# Altium schematic sheet Sheet1.SchDoc
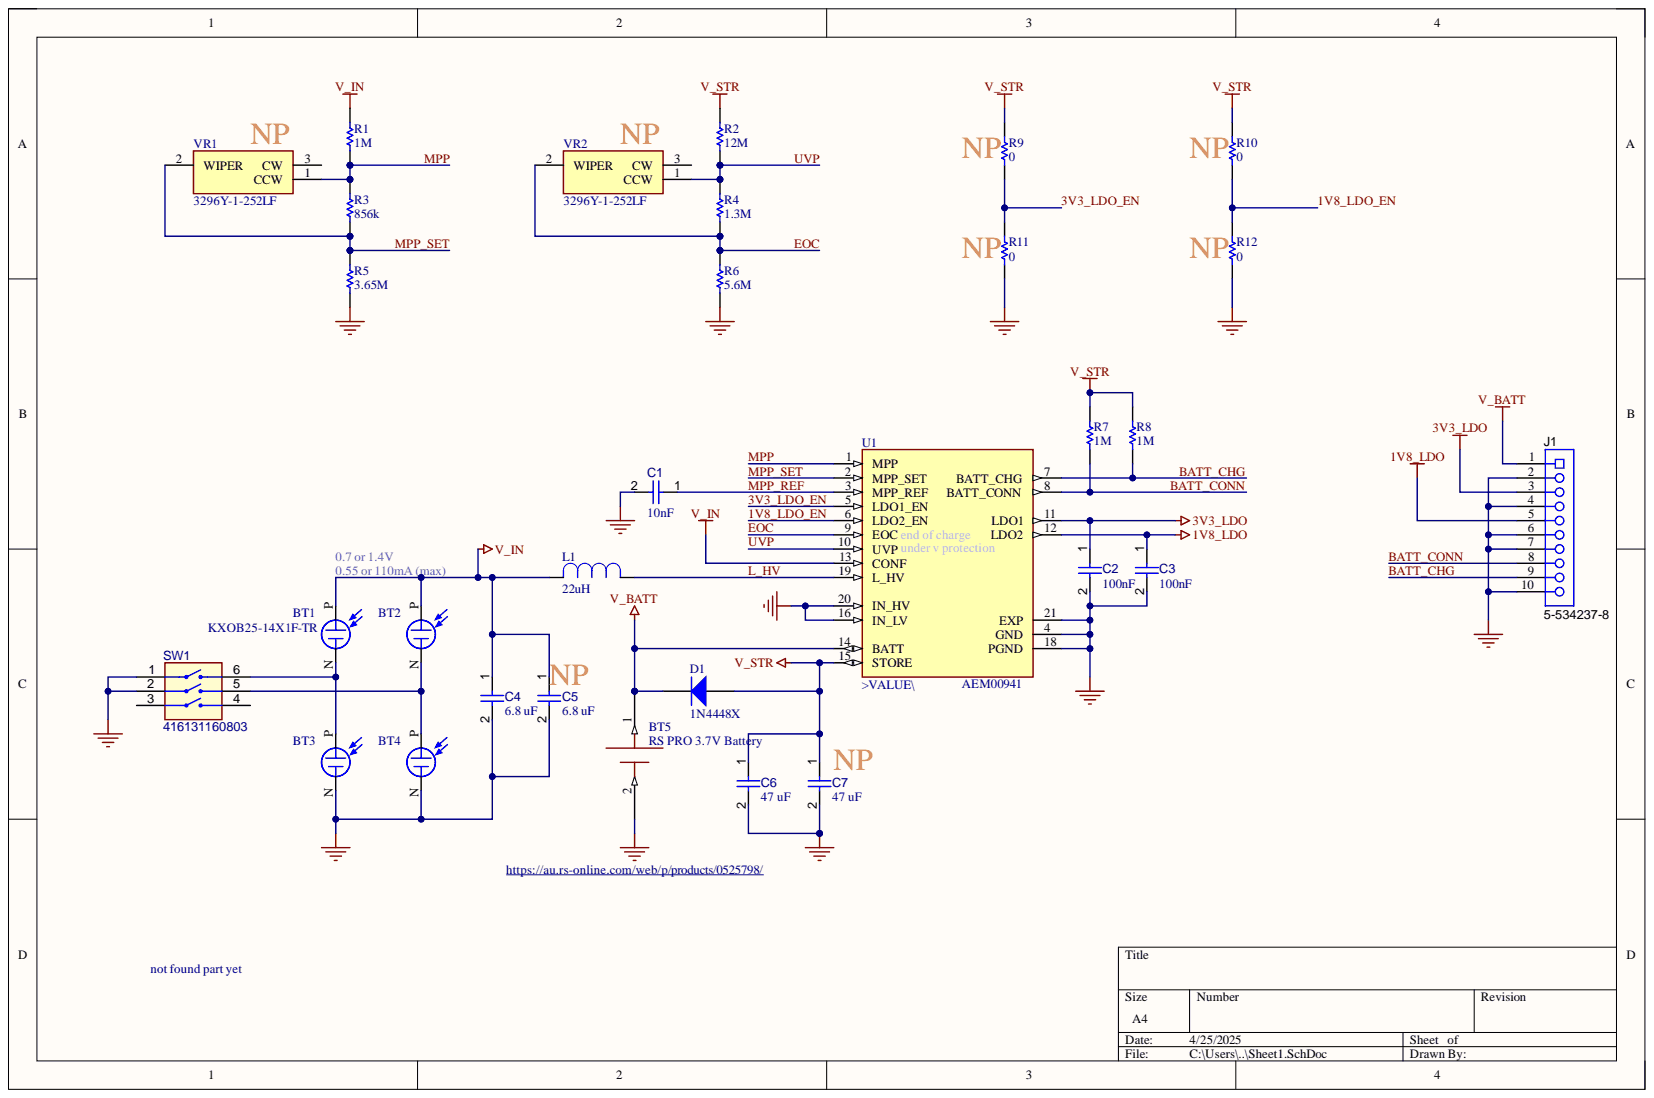
[diagram p0: Sheet1.SchDoc]

## Parts
BT1  KXOB25-14X1F-TR  [SOLAR_KXOB25-14X1F-TR]
BT2  KXOB25-14X1F-TR  [SOLAR_KXOB25-14X1F-TR]
BT3  KXOB25-14X1F-TR  [SOLAR_KXOB25-14X1F-TR]
BT4  KXOB25-14X1F-TR  [SOLAR_KXOB25-14X1F-TR]
BT5  RS PRO 3.7V Battery
C1  value 10nF  [CAPC1608X94X35NL15T15]  (hidden comment: Capacitor 1 uF +/- 10% 16 V 0603)
C2  value 100nF  [CAPC1608X94X35NL15T15]  (hidden comment: Capacitor 1 uF +/- 10% 16 V 0603)
C3  value 100nF  [CAPC1608X94X35NL15T15]  (hidden comment: Capacitor 1 uF +/- 10% 16 V 0603)
C4  value 6.8 uF  [CAPC0805(2012)145_L]  (hidden comment: Capacitor 100µF +/-20% 6.3V 0805)
C5  value 6.8 uF  [CAPC0805(2012)145_L]  (hidden comment: Capacitor 100µF +/-20% 6.3V 0805)
C6  value 47 uF  [CAPC0805(2012)145_L]  (hidden comment: Capacitor 100µF +/-20% 6.3V 0805)
C7  value 47 uF  [CAPC0805(2012)145_L]  (hidden comment: Capacitor 100µF +/-20% 6.3V 0805)
D1  1N4448X  [FAIR-SOD-323_V]
J1  5-534237-8  [TECO-5-534237-8_V]
L1  22uH  [INDM125125X600N]
R1  value 1M  [RESC1608X55X25ML10T15]  (hidden comment: Resistor 0R +/- 5% 0603 100 mW)
R2  value 12M  [RESC1608X55X25ML10T15]  (hidden comment: Resistor 0R +/- 5% 0603 100 mW)
R3  value 856k  [RESC1608X55X25ML10T15]  (hidden comment: Resistor 0R +/- 5% 0603 100 mW)
R4  value 1.3M  [RESC1608X55X25ML10T15]  (hidden comment: Resistor 0R +/- 5% 0603 100 mW)
R5  value 3.65M  [RESC1608X55X25ML10T15]  (hidden comment: Resistor 0R +/- 5% 0603 100 mW)
R6  value 5.6M  [RESC1608X55X25ML10T15]  (hidden comment: Resistor 0R +/- 5% 0603 100 mW)
R7  value 1M  [RESC1608X55X25ML10T15]  (hidden comment: Resistor 0R +/- 5% 0603 100 mW)
R8  value 1M  [RESC1608X55X25ML10T15]  (hidden comment: Resistor 0R +/- 5% 0603 100 mW)
R9  value 0  [RESC1005X40X25ML05T10]  (hidden comment: Resistor 0R 0402 63 mW)
R10  value 0  [RESC1005X40X25ML05T10]  (hidden comment: Resistor 0R 0402 63 mW)
R11  value 0  [RESC1005X40X25ML05T10]  (hidden comment: Resistor 0R 0402 63 mW)
R12  value 0  [RESC1005X40X25ML05T10]  (hidden comment: Resistor 0R 0402 63 mW)
SW1  416131160803  [416131160803]
U1  AEM00941  [QFN40P300X300X100-21N]
VR1  3296Y-1-252LF  [3296Y_1]
VR2  3296Y-1-252LF  [3296Y_1]

## Nets
1V8_LDO: C3.1 J1.5 U1.12(LDO2)
3V3_LDO: C2.1 J1.3 U1.11(LDO1)
GND: BT3.N BT4.N BT5.2 C1.2 C2.2 C3.2 C4.2 C5.2 C6.2 C7.2 J1.2 J1.4 J1.6 J1.7 J1.10 R5.1 R6.1 R11.1 R12.1 SW1.1 SW1.2 U1.4(GND) U1.16(IN_LV) U1.18(PGND) U1.20(IN_HV) U1.21(EXP)
V_BATT: BT5.1 D1.2 J1.1 U1.14(BATT)
V_IN: BT1.P BT2.P C4.1 C5.1 L1.2 R1.2 U1.13(CONF)
V_STR: C6.1 C7.1 D1.1 R2.2 R7.2 R8.2 R9.2 R10.2 U1.15(STORE)
1V8_LDO_EN: R10.1 R12.2 U1.6(LDO2_EN)
3V3_LDO_EN: R9.1 R11.2 U1.5(LDO1_EN)
BATT_CHG: J1.9 R8.1 U1.7(BATT_CHG)
BATT_CONN: J1.8 R7.1 U1.8(BATT_CONN)
EOC: R4.1 R6.2 U1.9 VR2.2
L_HV: L1.1 U1.19(L_HV)
MPP: R1.1 R3.2 U1.1(MPP) VR1.1
MPP_REF: C1.1 U1.3(MPP_REF)
MPP_SET: R3.1 R5.2 U1.2(MPP_SET) VR1.2
UVP: R2.1 R4.2 U1.10(UVP_under_v_protection) VR2.1
NetBT1_N: BT1.N BT3.P SW1.6
NetBT2_N: BT2.N BT4.P SW1.5

Not connected: SW1 3 4; VR1 3; VR2 3

## Library data of the parts
BT1-BT4 = KXOB25-14X1F-TR: Monocrystalline Solar Cell 30.7 mW 690 mV; MF: ANYSOLAR Ltd; Check_prices: https://www.snapeda.com/parts/KXOB25-14X1F-TR/Sola/view-part/?ref=eda; Component Kind: Standard; Library Name: KXOB25-14X1F-TR.SchLib; MP: KXOB25-14X1F-TR; Package: None; SnapEDA_Link: https://www.snapeda.com/parts/KXOB25-14X1F-TR/Sola/view-part/?ref=snap
C1-C3 = CMP-001-00003-6: Chip Capacitor, 1 uF, +/- 10%, 16 V, 0603 (1608 Metric); Capacitor Type: Ceramic; Voltage Rating: 16V; Case/Package: 0603; Component Kind: Standard; Max Operating Temperature: 125°C; Min Operating Temperature: -55°C; Mounting Technology: SM; Pins: 2; RoHS Compliant: True; Tolerance: 10%; Library Name: University of New South Wales
C4 = CMP-001-00054-4: Chip Capacitor, 100µF +/-20%, 6.3V, 0805, Thickness 1.45 mm; Tolerance: 10%; Value: 6.8 uF; Case/Package: 0805; Component Kind: Standard; Max Operating Temperature: 85°C; Min Operating Temperature: -55°C; Mounting Technology: SMT; Pins: 2; Voltage Rating: 6.3V; Library Name: University of New South Wales
C5-C7 = CMP-001-00054-4: Chip Capacitor, 100µF +/-20%, 6.3V, 0805, Thickness 1.45 mm; Tolerance: 10%; Case/Package: 0805; Component Kind: Standard; Max Operating Temperature: 85°C; Min Operating Temperature: -55°C; Mounting Technology: SMT; Pins: 2; Voltage Rating: 6.3V; Library Name: University of New South Wales
D1 = CMP-004-00001-2: Small Signal Diode, 75 V, 0.15 A, -55 to 150 degC, 2-Pin SOD-323, RoHS, Tape and Reel; Manufacturer: Fairchild; Capacitance: -323f; Forward Current: 150mA; Forward Voltage: 1V; Peak Reverse Current: 5uA; Recovery Time: 4ns; Case/Package: SOD-323; Component Kind: Standard; Datasheet URL: https://www.fairchildsemi.com/datasheets/1N/1N4148WS.pdf; Datasheet Version: Rev. 1.2, 03/2015; Manufacturer URL: https://www.fairchildsemi.com/; Max Operating Temperature: 150°C; Min Operating Temperature: -55°C; Mounting Technology: SM; Package Description: 2-Pin Small Outline Diode Flat Lead, Body 1.7 x 1.25 mm; Package Quantity: 3000; Package Reference: SOD-323; Packaging: TapeandReel; Pins: 2; RoHS Compliant: True; Library Name: University of New South Wales
J1 = CMP-002-00019-2: Female Receptacle, Pitch 2.54 mm, 1 x 10 Position, Height 8.64 mm, Tail Length 2.92 mm, -65 to 105 degC, RoHS, Tube; Manufacturer: TE Connectivity; Connector Type: Header; Current Rating: 2A; Max Contact Resistance: 12mohm; Rows: 1; Voltage Rating: 750V; Case/Package: 5-534237-8; Colour: Black; Component Kind: Standard; Contact Finish: Gold; Contact Material: Bronze; Contact Plating: Gold; Datasheet URL: http://www.te.com/commerce/DocumentDelivery/DDEController?Action=showdoc&DocId=Customer+Drawing%7F534237%7FR5%7Fpdf%7FEnglish%7FENG_CD_534237_R5.pdf%7F5-534237-8; Datasheet Version: Apr-13; Gender: Female; Lead Pitch: 2.54mm; Manufacturer URL: http://www.te.com/; Max Operating Temperature: 105°C; Min Operating Temperature: -65°C; Mounting Technology: TH; Package Description: Female Receptacle, Pitch 2.54 mm, 1 x 10 Position, Height 8.64 mm, Tail Length 2.92 mm; Package Quantity: 22; Package Reference: 5-534237-8; Packaging: Rail/Tube; Pins: 10; RoHS Compliant: True; Library Name: University of New South Wales
L1 = DR125-471-R: INDUCTOR, SHIELDED, 470UH, 1.02A, SMD; Product Range:COILTRONICS - DR125 Series; Inductance:470ÂµH; Inductance Tolerance:Â± 20%; Inductor Construction:Shielded; DC Resistance Max:-; RMS Current (Irms):870mA; Packaging:Cut Tape; MF: Eaton; MP: DR125471R; Check_prices: https://www.snapeda.com/parts/DR125471R/Eaton/view-part/?ref=eda; Component Kind: Standard; Library Name: DR125-471-R.SchLib; Package: Non Standard Coiltronics / Eaton; SnapEDA_Link: https://www.snapeda.com/parts/DR125471R/Eaton/view-part/?ref=snap
R1-R8 = CMP-009-00006-5: Chip Resistor, 0 Ohm, 0.1 W, -55 to 155 degC, 0603 (1608 Metric); Power: 100mW; Voltage Rating: 50V; Case/Package: 0603; Component Kind: Standard; Max Operating Temperature: 155°C; Min Operating Temperature: -55°C; Mounting Technology: SM; Pins: 2; RoHS Compliant: True; Tolerance: 5%; Library Name: University of New South Wales
R9-R12 = CMP-009-00004-5: Chip Resistor, 0 Ohm, 0.063 W, -55 to 155 degC, 0402 (1005 Metric); Power: 63mW; Voltage Rating: 50V; Case/Package: 0402; Component Kind: Standard; Max Operating Temperature: 155°C; Min Operating Temperature: -55°C; Mounting Technology: SM; Pins: 2; RoHS Compliant: True; Library Name: University of New South Wales
SW1 = CMP-011-00012-3: WS-DISV 1.27 mm small compact SMD Dip Switch with top tape; Component Kind: Standard; ComponentLink1Description: Manufacturer URL; ComponentLink1URL: http://www.we-online.com; ComponentLink2Description: Datasheet; ComponentLink2URL: http://katalog.we-online.de/em/datasheet/4161311608xx.pdf; Pins: 6; Library Name: University of New South Wales
U1 = SPV1050TTR: Charger IC Multi-Chemistry 20-VFQFPN (3x3); MF: STMicroelectronics; Check_prices: https://www.snapeda.com/parts/SPV1050TTR/STMicroelectronics/view-part/?ref=eda; Component Kind: Standard; Library Name: SPV1050TTR.SchLib; MP: SPV1050TTR; Package: VFQFN-20 STMicroelectronics; SnapEDA_Link: https://www.snapeda.com/parts/SPV1050TTR/STMicroelectronics/view-part/?ref=snap
VR1 VR2 = 3296Y-1-252LF: Variable Resistor; Manufacturer_Name: Bourns; Arrow Part Number: 3296Y-1-252LF; Arrow Price/Stock: https://www.arrow.com/en/products/3296y-1-252lf/bourns; Component Kind: Standard; Datasheet Link: https://datasheet.datasheetarchive.com/originals/distributors/SFDatasheet-6/sf-000139911.pdf; Manufacturer_Part_Number: 3296Y-1-252LF; Mouser Part Number: 652-3296Y-1-252LF; Mouser Price/Stock: https://www.mouser.co.uk/ProductDetail/Bourns/3296Y-1-252LF?qs=eK3J1aEiRPuCAxSfNwIyLg%3D%3D
BT5 = RS PRO 3.7V Battery: Component Kind: Standard; Library Name: Schlib3.SchLib
